# Altium schematic sheet AD7124_AD8659ARZ_edel_403_v1.SchDoc(AD7124_AD8659ARZ_edel_403_v1)
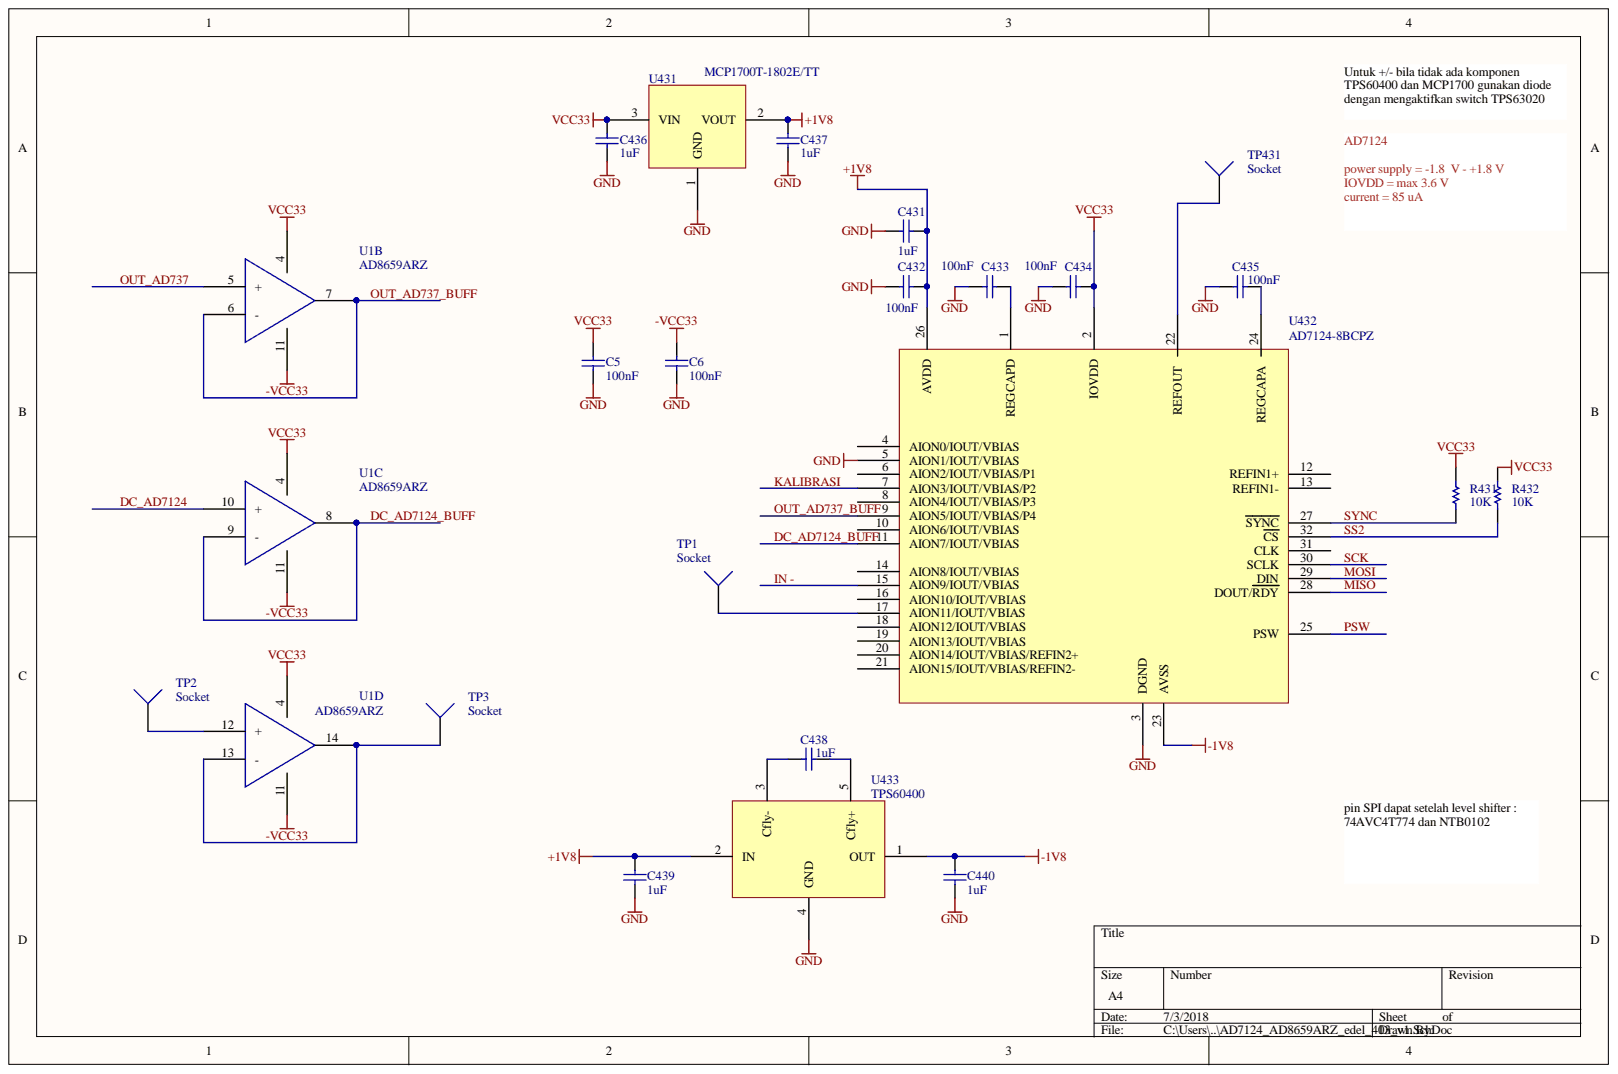
[diagram p0: AD7124_AD8659ARZ_edel_403_v1.SchDoc]

## Parts
C5  100nF  [0805]
C6  100nF  [0805]
C431  1uF  [0805]
C432  100nF  [0805]
C433  100nF  [0805]
C434  100nF  [0805]
C435  100nF  [0805]
C436  1uF  [0805]
C437  1uF  [0805]
C438  1uF  [0805]
C439  1uF  [0805]
C440  1uF  [0805]
R431  10K  [0805]
R432  10K  [0805]
TP1  Socket  [PIN1]
TP2  Socket  [PIN1]
TP3  Socket  [PIN1]
TP431  Socket  [PIN1]
U1  AD8659ARZ  [AD8659]  (parts B,C,D)
U431  MCP1700T-1802E/TT  [SOT-23_N]
U432  AD7124-8BCPZ  [AD7124-8BCPZ-ULTRA]
U433  TPS60400  [TPS60400]

## Nets
+1V8: C431.2 C432.2 C437.2 C439.2 U431.2 U432.26(AVDD) U433.2(IN)
-1V8: C440.2 U432.23(AVSS) U433.1(OUT)
-VCC33: C6.2 U1.11
GND: C5.1 C6.1 C431.1 C432.1 C433.1 C434.1 C435.1 C436.1 C437.1 C439.1 C440.1 U431.1 U432.3(DGND) U432.5(AION1/IOUT/VBIAS) U433.4(GND)
VCC33: C5.2 C434.2 C436.2 R431.2 R432.2 U1.4 U431.3 U432.2(IOVDD)
DC_AD7124: U1.10(+)
DC_AD7124_BUFF: U1.8 U1.9(-) U432.11(AION7/IOUT/VBIAS)
IN -: U432.15(AION9/IOUT/VBIAS)
KALIBRASI: U432.7(AION3/IOUT/VBIAS/P2)
MISO: U432.28(DOUT/RDY)
MOSI: U432.29(DIN)
OUT_AD737: U1.5(+)
OUT_AD737_BUFF: U1.6(-) U1.7 U432.9(AION5/IOUT/VBIAS/P4)
PSW: U432.25(PSW)
SCK: U432.30(SCLK)
SS2: R432.1 U432.32(CS)
SYNC: R431.1 U432.27(SYNC)
NetC433_2: C433.2 U432.1(REGCAPD)
NetC435_2: C435.2 U432.24(REGCAPA)
NetC438_1: C438.1 U433.3(Cfly-)
NetC438_2: C438.2 U433.5(Cfly+)
NetTP1_1: TP1.1 U432.17(AION11/IOUT/VBIAS)
NetTP2_1: TP2.1 U1.12(+)
NetTP3_1: TP3.1 U1.13(-) U1.14
NetTP431_1: TP431.1 U432.22(REFOUT)

Not connected: U432 4(AION0/IOUT/VBIAS) 6(AION2/IOUT/VBIAS/P1) 8(AION4/IOUT/VBIAS/P3) 10(AION6/IOUT/VBIAS) 12(REFIN1+) 13(REFIN1-) 14(AION8/IOUT/VBIAS) 16(AION10/IOUT/VBIAS) 18(AION12/IOUT/VBIAS) 19(AION13/IOUT/VBIAS) 20(AION14/IOUT/VBIAS/REFIN2+) 21(AION15/IOUT/VBIAS/REFIN2-) 31(CLK)

## Library data of the parts
C5 C6 C431-C440 = Cap: Capacitor; Simulation: CAP; Signal Integrity: Cap; Published: 8-Jun-2000; PackageReference: RAD-0.3; PackageDescription: Radial Cap, Thru-Hole; 2 Leads; 0.3 in Pin Spacing; Library Name: Miscellaneous Devices.IntLib; LatestRevisionNote: Re-released for DXP Platform.; LatestRevisionDate: 17-Jul-2002; Component Kind: Standard; Publisher: Altium Limited
  C5 C6 C432-C435: Value: 100nF
  C431 C436-C440: Value: 1uF
R431 R432 = Res1: Resistor; Value: 10K; Simulation: RESISTOR; Signal Integrity: Res; Published: 8-Jun-2000; PackageReference: AXIAL-0.3; PackageDescription: Axial Device, Thru-Hole; 2 Leads; 0.3 in Pin Spacing; Library Name: Miscellaneous Devices.IntLib; LatestRevisionNote: Re-released for DXP Platform.; LatestRevisionDate: 17-Jul-2002; Component Kind: Standard; Publisher: Altium Limited
TP1-TP3 TP431 = Socket: Signal Integrity: Connector; Library Name: Miscellaneous Connectors.IntLib; LatestRevisionNote: Re-released for DXP Platform.; LatestRevisionDate: 17-Jul-2002; Description: Socket; Component Kind: Standard; Publisher: Altium Limited
U1 = AD8659ARZ: Library Name: SmartTB.SchLib; Component Kind: Standard
U431 = MCP1700T-1802E/TT: Library Name: SmartTB.SchLib; Component Kind: Standard
U432 = AD7124-8BCPZ: Library Name: SmartTB.SchLib; Component Kind: Standard
U433 = TPS60400: Library Name: SmartTB.SchLib; Component Kind: Standard
